# Altium schematic sheet Interface.SchDoc(Interface)
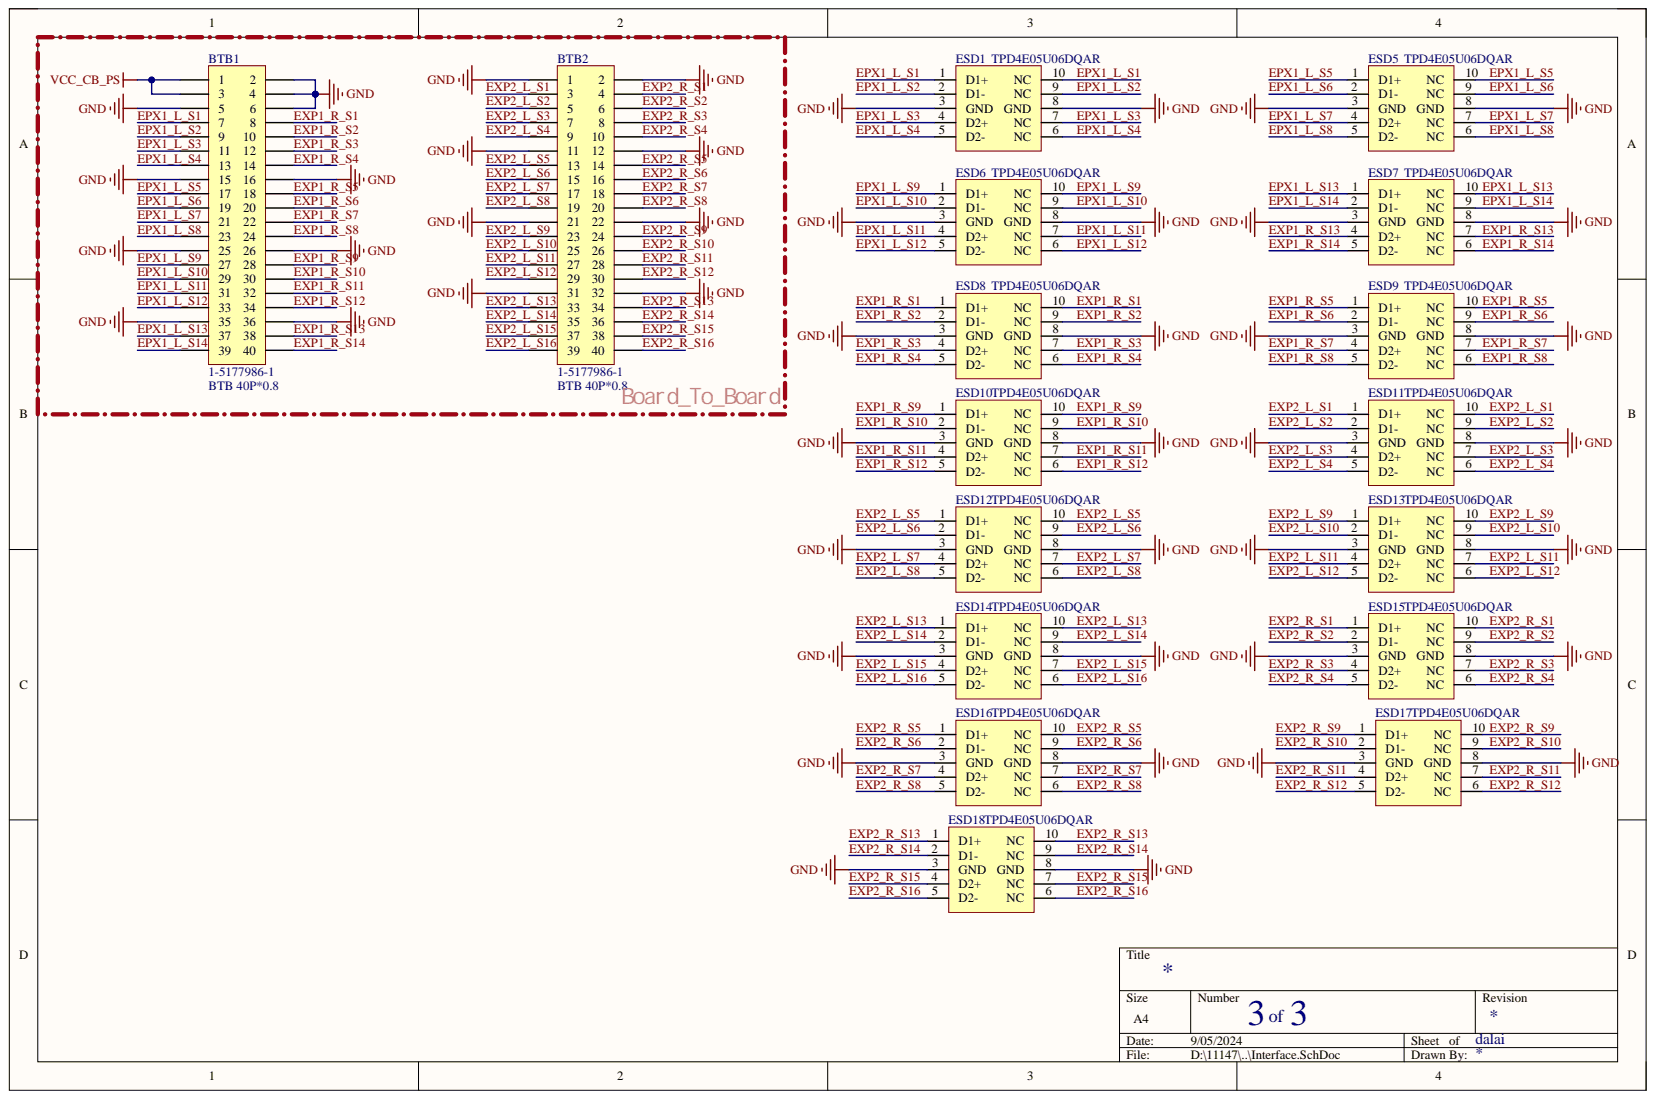
[diagram p0: Interface.SchDoc]

## Parts
BTB1  1-5177986-1  value BTB 40P*0.8  [CONN-SMD_40P-P0.80_XKB_X0802WVS-40ADS-LPV01]
BTB2  1-5177986-1  value BTB 40P*0.8  [CONN-SMD_40P-P0.80_XKB_X0802WVS-40ADS-LPV01]
ESD1  TPD4E05U06DQAR  [USON-10_L2.5-W1.0-P0.50-BL]
ESD5  TPD4E05U06DQAR  [USON-10_L2.5-W1.0-P0.50-BL]
ESD6  TPD4E05U06DQAR  [USON-10_L2.5-W1.0-P0.50-BL]
ESD7  TPD4E05U06DQAR  [USON-10_L2.5-W1.0-P0.50-BL]
ESD8  TPD4E05U06DQAR  [USON-10_L2.5-W1.0-P0.50-BL]
ESD9  TPD4E05U06DQAR  [USON-10_L2.5-W1.0-P0.50-BL]
ESD10  TPD4E05U06DQAR  [USON-10_L2.5-W1.0-P0.50-BL]
ESD11  TPD4E05U06DQAR  [USON-10_L2.5-W1.0-P0.50-BL]
ESD12  TPD4E05U06DQAR  [USON-10_L2.5-W1.0-P0.50-BL]
ESD13  TPD4E05U06DQAR  [USON-10_L2.5-W1.0-P0.50-BL]
ESD14  TPD4E05U06DQAR  [USON-10_L2.5-W1.0-P0.50-BL]
ESD15  TPD4E05U06DQAR  [USON-10_L2.5-W1.0-P0.50-BL]
ESD16  TPD4E05U06DQAR  [USON-10_L2.5-W1.0-P0.50-BL]
ESD17  TPD4E05U06DQAR  [USON-10_L2.5-W1.0-P0.50-BL]
ESD18  TPD4E05U06DQAR  [USON-10_L2.5-W1.0-P0.50-BL]

## Nets
GND: BTB1.2 BTB1.4 BTB1.5 BTB1.6 BTB1.15 BTB1.16 BTB1.25 BTB1.26 BTB1.35 BTB1.36 BTB2.1 BTB2.2 BTB2.11 BTB2.12 BTB2.21 BTB2.22 BTB2.31 BTB2.32 ESD1.3(GND) ESD1.8(GND) ESD5.3(GND) ESD5.8(GND) ESD6.3(GND) ESD6.8(GND) ESD7.3(GND) ESD7.8(GND) ESD8.3(GND) ESD8.8(GND) ESD9.3(GND) ESD9.8(GND) ESD10.3(GND) ESD10.8(GND) ESD11.3(GND) ESD11.8(GND) ESD12.3(GND) ESD12.8(GND) ESD13.3(GND) ESD13.8(GND) ESD14.3(GND) ESD14.8(GND) ESD15.3(GND) ESD15.8(GND) ESD16.3(GND) ESD16.8(GND) ESD17.3(GND) ESD17.8(GND) ESD18.3(GND) ESD18.8(GND)
VCC_CB_PS: BTB1.1 BTB1.3
EPX1_L_S1: BTB1.7 ESD1.1(D1+) ESD1.10(NC)
EPX1_L_S2: BTB1.9 ESD1.2(D1-) ESD1.9(NC)
EPX1_L_S3: BTB1.11 ESD1.4(D2+) ESD1.7(NC)
EPX1_L_S4: BTB1.13 ESD1.5(D2-) ESD1.6(NC)
EPX1_L_S5: BTB1.17 ESD5.1(D1+) ESD5.10(NC)
EPX1_L_S6: BTB1.19 ESD5.2(D1-) ESD5.9(NC)
EPX1_L_S7: BTB1.21 ESD5.4(D2+) ESD5.7(NC)
EPX1_L_S8: BTB1.23 ESD5.5(D2-) ESD5.6(NC)
EPX1_L_S9: BTB1.27 ESD6.1(D1+) ESD6.10(NC)
EPX1_L_S10: BTB1.29 ESD6.2(D1-) ESD6.9(NC)
EPX1_L_S11: BTB1.31 ESD6.4(D2+) ESD6.7(NC)
EPX1_L_S12: BTB1.33 ESD6.5(D2-) ESD6.6(NC)
EPX1_L_S13: BTB1.37 ESD7.1(D1+) ESD7.10(NC)
EPX1_L_S14: BTB1.39 ESD7.2(D1-) ESD7.9(NC)
EXP1_R_S1: BTB1.8 ESD8.1(D1+) ESD8.10(NC)
EXP1_R_S2: BTB1.10 ESD8.2(D1-) ESD8.9(NC)
EXP1_R_S3: BTB1.12 ESD8.4(D2+) ESD8.7(NC)
EXP1_R_S4: BTB1.14 ESD8.5(D2-) ESD8.6(NC)
EXP1_R_S5: BTB1.18 ESD9.1(D1+) ESD9.10(NC)
EXP1_R_S6: BTB1.20 ESD9.2(D1-) ESD9.9(NC)
EXP1_R_S7: BTB1.22 ESD9.4(D2+) ESD9.7(NC)
EXP1_R_S8: BTB1.24 ESD9.5(D2-) ESD9.6(NC)
EXP1_R_S9: BTB1.28 ESD10.1(D1+) ESD10.10(NC)
EXP1_R_S10: BTB1.30 ESD10.2(D1-) ESD10.9(NC)
EXP1_R_S11: BTB1.32 ESD10.4(D2+) ESD10.7(NC)
EXP1_R_S12: BTB1.34 ESD10.5(D2-) ESD10.6(NC)
EXP1_R_S13: BTB1.38 ESD7.4(D2+) ESD7.7(NC)
EXP1_R_S14: BTB1.40 ESD7.5(D2-) ESD7.6(NC)
EXP2_L_S1: BTB2.3 ESD11.1(D1+) ESD11.10(NC)
EXP2_L_S2: BTB2.5 ESD11.2(D1-) ESD11.9(NC)
EXP2_L_S3: BTB2.7 ESD11.4(D2+) ESD11.7(NC)
EXP2_L_S4: BTB2.9 ESD11.5(D2-) ESD11.6(NC)
EXP2_L_S5: BTB2.13 ESD12.1(D1+) ESD12.10(NC)
EXP2_L_S6: BTB2.15 ESD12.2(D1-) ESD12.9(NC)
EXP2_L_S7: BTB2.17 ESD12.4(D2+) ESD12.7(NC)
EXP2_L_S8: BTB2.19 ESD12.5(D2-) ESD12.6(NC)
EXP2_L_S9: BTB2.23 ESD13.1(D1+) ESD13.10(NC)
EXP2_L_S10: BTB2.25 ESD13.2(D1-) ESD13.9(NC)
EXP2_L_S11: BTB2.27 ESD13.4(D2+) ESD13.7(NC)
EXP2_L_S12: BTB2.29 ESD13.5(D2-) ESD13.6(NC)
EXP2_L_S13: BTB2.33 ESD14.1(D1+) ESD14.10(NC)
EXP2_L_S14: BTB2.35 ESD14.2(D1-) ESD14.9(NC)
EXP2_L_S15: BTB2.37 ESD14.4(D2+) ESD14.7(NC)
EXP2_L_S16: BTB2.39 ESD14.5(D2-) ESD14.6(NC)
EXP2_R_S1: BTB2.4 ESD15.1(D1+) ESD15.10(NC)
EXP2_R_S2: BTB2.6 ESD15.2(D1-) ESD15.9(NC)
EXP2_R_S3: BTB2.8 ESD15.4(D2+) ESD15.7(NC)
EXP2_R_S4: BTB2.10 ESD15.5(D2-) ESD15.6(NC)
EXP2_R_S5: BTB2.14 ESD16.1(D1+) ESD16.10(NC)
EXP2_R_S6: BTB2.16 ESD16.2(D1-) ESD16.9(NC)
EXP2_R_S7: BTB2.18 ESD16.4(D2+) ESD16.7(NC)
EXP2_R_S8: BTB2.20 ESD16.5(D2-) ESD16.6(NC)
EXP2_R_S9: BTB2.24 ESD17.1(D1+) ESD17.10(NC)
EXP2_R_S10: BTB2.26 ESD17.2(D1-) ESD17.9(NC)
EXP2_R_S11: BTB2.28 ESD17.4(D2+) ESD17.7(NC)
EXP2_R_S12: BTB2.30 ESD17.5(D2-) ESD17.6(NC)
EXP2_R_S13: BTB2.34 ESD18.1(D1+) ESD18.10(NC)
EXP2_R_S14: BTB2.36 ESD18.2(D1-) ESD18.9(NC)
EXP2_R_S15: BTB2.38 ESD18.4(D2+) ESD18.7(NC)
EXP2_R_S16: BTB2.40 ESD18.5(D2-) ESD18.6(NC)

## Library data of the parts
BTB1 BTB2 = Header 20X2: Header, 20-Pin, Dual row; Component Kind: Standard; Library Name: Miscellaneous Connectors.IntLib; Signal Integrity: Connector
ESD1 ESD5-ESD18 = TPD4E05U06DQAR: ESD for SSUSB; Component Kind: Standard; Library Name: My package.SchLib
